# Altium schematic sheet CW551_Mainboard_MCU.SchDoc
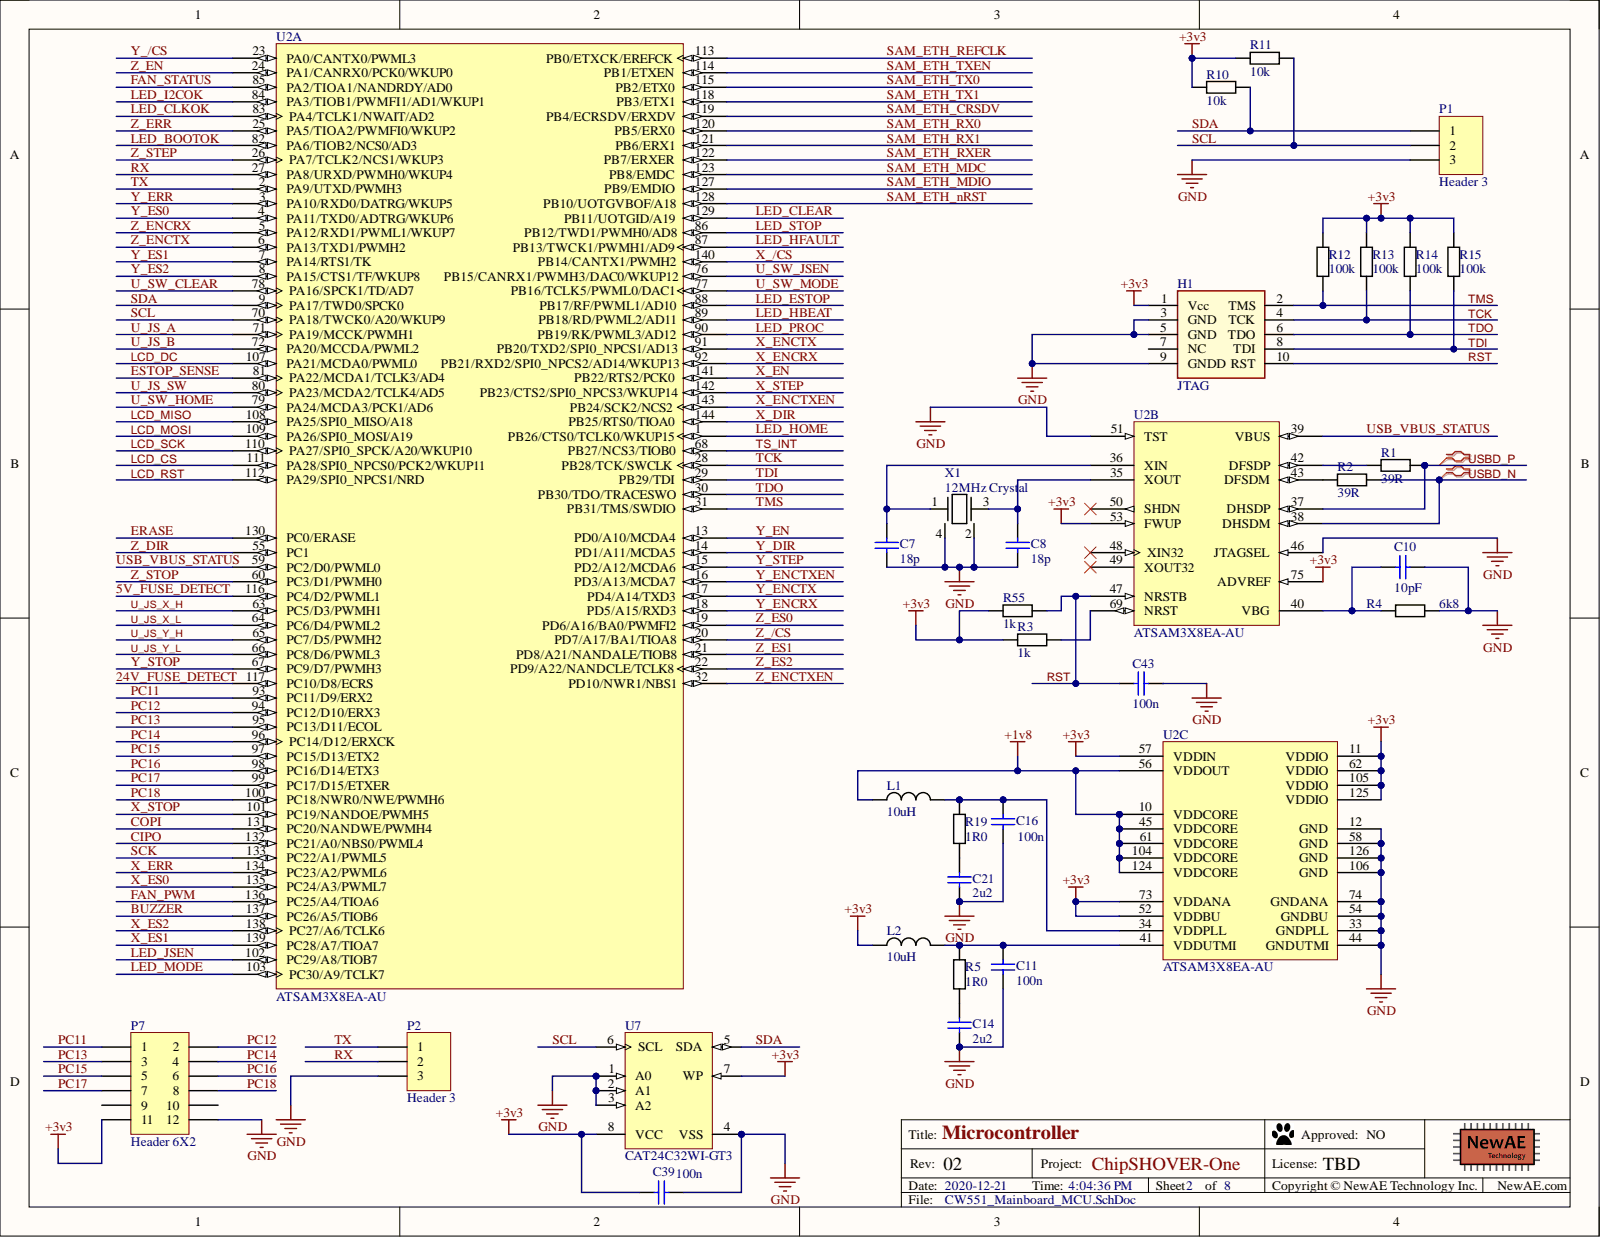
[diagram p0: CW551_Mainboard_MCU.SchDoc]

## Parts
C7  18p  [CAPC0402_M]
C8  18p  [CAPC0402_M]
C10  10pF  [CAPC0603_M]
C11  100n  [CAPC0603_M]
C14  2u2  [CAPC0603_M]
C16  100n  [CAPC0603_M]
C21  2u2  [CAPC0603_M]
C39  100n  [CAPC0603_M]
C43  100n  [CAPC0603_M]
H1  JTAG  [0.5mm_SMD_Header_5x2]
L1  10uH  [IND_0603]
L2  10uH  [IND_0603]
P1  Header 3  [HDR1X3]
P2  Header 3  [HDR1X3]
P7  Header 6X2  [HDR2X6]
R1  39R  [RESC1608X55N]
R2  39R  [RESC1608X55N]
R3  1k  [0603]
R4  6k8  [0603]
R5  1R0  [RESC1608X55N]
R10  10k  [0603]
R11  10k  [0603]
R12  100k  [RESC1608X55N]
R13  100k  [RESC1608X55N]
R14  100k  [RESC1608X55N]
R15  100k  [RESC1608X55N]
R19  1R0  [RESC1608X55N]
R55  1k  [0603]
U2  ATSAM3X8EA-AU  [LQFP144_L]  (parts A,B,C)
U7  CAT24C32WI-GT3  [ONSC-SOIC-8-751BD-01_M]
X1  12MHz Crystal  Component Group: Crystal  [ABM8G]

## Nets
+1v8: L1.1 U2.10(VDDCORE) U2.45(VDDCORE) U2.56(VDDOUT) U2.61(VDDCORE) U2.104(VDDCORE) U2.124(VDDCORE)
+3v3: C39.1 H1.1(Vcc) L2.1 P7.11 R3.2 R10.1 R11.1 R12.1 R13.1 R14.1 R15.1 R55.2 U2.11(VDDIO) U2.52(VDDBU) U2.53(FWUP) U2.57(VDDIN) U2.62(VDDIO) U2.73(VDDANA) U2.75(ADVREF) U2.105(VDDIO) U2.125(VDDIO) U7.7(WP) U7.8(VCC)
GND: C7.2 C8.2 C10.1 C11.2 C14.2 C16.2 C21.2 C39.2 C43.2 H1.3(GND) H1.5(GND) H1.9(GNDD) P1.3 P2.3 P7.12 R4.1 U2.12(GND) U2.33(GNDPLL) U2.44(GNDUTMI) U2.46(JTAGSEL) U2.51(TST) U2.54(GNDBU) U2.58(GND) U2.74(GNDANA) U2.106(GND) U2.126(GND) U7.1(A0) U7.2(A1) U7.3(A2) U7.4(VSS) X1.2 X1.4
5V_FUSE_DETECT: U2.116(PC4/D2/PWML1)
24V_FUSE_DETECT: U2.117(PC10/D8/ECRS)
BUZZER: U2.137(PC26/A5/TIOB6)
CIPO: U2.132(PC21/A0/NBS0/PWML4)
COPI: U2.131(PC20/NANDWE/PWMH4)
ERASE: U2.130(PC0/ERASE)
ESTOP_SENSE: U2.81(PA22/MCDA1/TCLK3/AD4)
FAN_PWM: U2.136(PC25/A4/TIOA6)
FAN_STATUS: U2.85(PA2/TIOA1/NANDRDY/AD0)
LCD_CS: U2.111(PA28/SPI0_NPCS0/PCK2/WKUP11)
LCD_DC: U2.107(PA21/MCDA0/PWML0)
LCD_MISO: U2.108(PA25/SPI0_MISO/A18)
LCD_MOSI: U2.109(PA26/SPI0_MOSI/A19)
LCD_RST: U2.112(PA29/SPI0_NPCS1/NRD)
LCD_SCK: U2.110(PA27/SPI0_SPCK/A20/WKUP10)
LED_BOOTOK: U2.82(PA6/TIOB2/NCS0/AD3)
LED_CLEAR: U2.129(PB11/UOTGID/A19)
LED_CLKOK: U2.83(PA4/TCLK1/NWAIT/AD2)
LED_ESTOP: U2.88(PB17/RF/PWML1/AD10)
LED_HBEAT: U2.89(PB18/RD/PWML2/AD11)
LED_HFAULT: U2.87(PB13/TWCK1/PWMH1/AD9)
LED_HOME: U2.1(PB26/CTS0/TCLK0/WKUP15)
LED_I2COK: U2.84(PA3/TIOB1/PWMFI1/AD1/WKUP1)
LED_JSEN: U2.102(PC29/A8/TIOB7)
LED_MODE: U2.103(PC30/A9/TCLK7)
LED_PROC: U2.90(PB19/RK/PWML3/AD12)
LED_STOP: U2.86(PB12/TWD1/PWMH0/AD8)
PC11: P7.1 U2.93(PC11/D9/ERX2)
PC12: P7.2 U2.94(PC12/D10/ERX3)
PC13: P7.3 U2.95(PC13/D11/ECOL)
PC14: P7.4 U2.96(PC14/D12/ERXCK)
PC15: P7.5 U2.97(PC15/D13/ETX2)
PC16: P7.6 U2.98(PC16/D14/ETX3)
PC17: P7.7 U2.99(PC17/D15/ETXER)
PC18: P7.8 U2.100(PC18/NWR0/NWE/PWMH6)
RST: C43.1 H1.10(RST) R55.1 U2.47(NRSTB)
RX: P2.2 U2.27(PA8/URXD/PWMH0/WKUP4)
SAM_ETH_CRSDV: U2.119(PB4/ECRSDV/ERXDV)
SAM_ETH_MDC: U2.123(PB8/EMDC)
SAM_ETH_MDIO: U2.127(PB9/EMDIO)
SAM_ETH_nRST: U2.128(PB10/UOTGVBOF/A18)
SAM_ETH_REFCLK: U2.113(PB0/ETXCK/EREFCK)
SAM_ETH_RX0: U2.120(PB5/ERX0)
SAM_ETH_RX1: U2.121(PB6/ERX1)
SAM_ETH_RXER: U2.122(PB7/ERXER)
SAM_ETH_TX0: U2.115(PB2/ETX0)
SAM_ETH_TX1: U2.118(PB3/ETX1)
SAM_ETH_TXEN: U2.114(PB1/ETXEN)
SCK: U2.133(PC22/A1/PWML5)
SCL: P1.2 R11.2 U2.70(PA18/TWCK0/A20/WKUP9) U7.6(SCL)
SDA: P1.1 R10.2 U2.9(PA17/TWD0/SPCK0) U7.5(SDA)
TCK: H1.4(TCK) R13.2 U2.28(PB28/TCK/SWCLK)
TDI: H1.8(TDI) R15.2 U2.29(PB29/TDI)
TDO: H1.6(TDO) R14.2 U2.30(PB30/TDO/TRACESWO)
TMS: H1.2(TMS) R12.2 U2.31(PB31/TMS/SWDIO)
TS_INT: U2.68(PB27/NCS3/TIOB0)
TX: P2.1 U2.2(PA9/UTXD/PWMH3)
U_JS_A: U2.71(PA19/MCCK/PWMH1)
U_JS_B: U2.72(PA20/MCCDA/PWML2)
U_JS_SW: U2.80(PA23/MCDA2/TCLK4/AD5)
U_JS_X_H: U2.63(PC5/D3/PWMH1)
U_JS_X_L: U2.64(PC6/D4/PWML2)
U_JS_Y_H: U2.65(PC7/D5/PWMH2)
U_JS_Y_L: U2.66(PC8/D6/PWML3)
U_SW_CLEAR: U2.78(PA16/SPCK1/TD/AD7)
U_SW_HOME: U2.79(PA24/MCDA3/PCK1/AD6)
U_SW_JSEN: U2.76(PB15/CANRX1/PWMH3/DAC0/WKUP12)
U_SW_MODE: U2.77(PB16/TCLK5/PWML0/DAC1)
USB_VBUS_STATUS: U2.39(VBUS) U2.59(PC2/D0/PWML0)
USBD_N: R2.1 U2.38(DHSDM)
USBD_P: R1.2 U2.37(DHSDP)
X_/CS: U2.140(PB14/CANTX1/PWMH2)
X_DIR: U2.144(PB25/RTS0/TIOA0)
X_EN: U2.141(PB22/RTS2/PCK0)
X_ENCRX: U2.92(PB21/RXD2/SPI0_NPCS2/AD14/WKUP13)
X_ENCTX: U2.91(PB20/TXD2/SPI0_NPCS1/AD13)
X_ENCTXEN: U2.143(PB24/SCK2/NCS2)
X_ERR: U2.134(PC23/A2/PWML6)
X_ES0: U2.135(PC24/A3/PWML7)
X_ES1: U2.139(PC28/A7/TIOA7)
X_ES2: U2.138(PC27/A6/TCLK6)
X_STEP: U2.142(PB23/CTS2/SPI0_NPCS3/WKUP14)
X_STOP: U2.101(PC19/NANDOE/PWMH5)
Y_/CS: U2.23(PA0/CANTX0/PWML3)
Y_DIR: U2.14(PD1/A11/MCDA5)
Y_EN: U2.13(PD0/A10/MCDA4)
Y_ENCRX: U2.18(PD5/A15/RXD3)
Y_ENCTX: U2.17(PD4/A14/TXD3)
Y_ENCTXEN: U2.16(PD3/A13/MCDA7)
Y_ERR: U2.3(PA10/RXD0/DATRG/WKUP5)
Y_ES0: U2.4(PA11/TXD0/ADTRG/WKUP6)
Y_ES1: U2.7(PA14/RTS1/TK)
Y_ES2: U2.8(PA15/CTS1/TF/WKUP8)
Y_STEP: U2.15(PD2/A12/MCDA6)
Y_STOP: U2.67(PC9/D7/PWMH3)
Z_/CS: U2.20(PD7/A17/BA1/TIOA8)
Z_DIR: U2.55(PC1)
Z_EN: U2.24(PA1/CANRX0/PCK0/WKUP0)
Z_ENCRX: U2.5(PA12/RXD1/PWML1/WKUP7)
Z_ENCTX: U2.6(PA13/TXD1/PWMH2)
Z_ENCTXEN: U2.32(PD10/NWR1/NBS1)
Z_ERR: U2.25(PA5/TIOA2/PWMFI0/WKUP2)
Z_ES0: U2.19(PD6/A16/BA0/PWMFI2)
Z_ES1: U2.21(PD8/A21/NANDALE/TIOB8)
Z_ES2: U2.22(PD9/A22/NANDCLE/TCLK8)
Z_STEP: U2.26(PA7/TCLK2/NCS1/WKUP3)
Z_STOP: U2.60(PC3/D1/PWMH0)
NetC7_1: C7.1 U2.36(XIN) X1.1
NetC8_1: C8.1 U2.35(XOUT) X1.3
NetC10_2: C10.2 R4.2 U2.40(VBG)
NetC11_1: C11.1 L2.2 R5.1 U2.41(VDDUTMI)
NetC14_1: C14.1 R5.2
NetC16_1: C16.1 L1.2 R19.1 U2.34(VDDPLL)
NetC21_1: C21.1 R19.2
NetR1_1: R1.1 U2.42(DFSDP)
NetR2_2: R2.2 U2.43(DFSDM)
NetR3_1: R3.1 U2.69(NRST)

Not connected: H1 7(NC); P7 9 10; U2 48(XIN32) 49(XOUT32) 50(SHDN)

## Library data of the parts
C7 C8 = CCHIP-18p-0402: 18p, 0402; Manufacture Part Number 1: GRM1555C1H180JA01D; Case-EIA: 0402; Component Group: Capacitor; 0_TEMP: CAPC0402_M; Component Kind: Standard; Component Type: Standard; LCSC Equivalent: C106202; Library Name: CW550_MAIN.SCHLIB; Mounting Technology: SMT; Part Number: CCHIP-18p-0402; Pin Count: 2; Supplier 1: Digi-Key; Supplier Part Number 1: 490-5858-1-ND; Value: 18p
C10 = CCHIP-100n-0603: Cap. 10pF, 1%, 0603; Manufacture 1: Kemet; Manufacture Part Number 1: C0603C100F5GAC7867; Part Number: X00X; Case-EIA: 0603; Case-Metric: 1608; Component Group: Capacitor; Rated Voltage: 50V; 0_TEMP: CAPC0603_M; Component Kind: Standard; Component Type: Standard; LCSC Equivalent: C1591; Library Name: CW550_MAIN.SCHLIB; Mounting Technology: SMT; Pin Count: 2; Supplier 1: Digi-Key; Supplier Part Number 1: 399-9958-1-ND; Value: 10pF
C11 C16 C39 C43 = CCHIP-100n-0603: 100n, 0603; Manufacture 1: Kemet; Manufacture Part Number 1: C0603C104K5RACTU; Case-EIA: 0603; Case-Metric: 1608; Component Group: Capacitor; Rated Voltage: 50V; 0_TEMP: CAPC0603_M; Component Kind: Standard; Component Type: Standard; LCSC Equivalent: C1591; Library Name: CW550_MAIN.SCHLIB; Mounting Technology: SMT; Part Number: CCHIP-100n-0603; Pin Count: 2; Supplier 1: Digi-Key; Supplier Part Number 1: 399-5089-2-ND; Value: 100n
C14 C21 = CCHIP-2u2-0603: 2u2, 0603; Manufacture 1: Samsung; Manufacture Part Number 1: CL10B225KP8NNNC; Case-EIA: 0603; Case-Metric: 1608; Component Group: Capacitor; Rated Voltage: 10VDC; 0_TEMP: CAPC0603_M; Component Kind: Standard; Component Type: Standard; LCSC Equivalent: C57895; Library Name: CW550_MAIN.SCHLIB; Mounting Technology: SMT; Part Number: CCHIP-2u2-0603; Pin Count: 2; Supplier 1: Digi-Key; Supplier Part Number 1: 1276-1134-2-ND; Value: 2u2
H1 = Cortex_Debug_Header_10Pin: 10 Pin Cortex Program & Debug 0.5mm pitch; Manufacture 1: Samtec Inc; Manufacture Part Number 1: FTSH-105-01-L-DV-007-K; Component Group: Connector; PP Material Stack: 0; 0_TEMP: 0.5mm_SMD_Header_5x2; Component Kind: Standard; Component Type: Standard; Functionality: JTAG; Library Name: CW550.SCHLIB; Mounting Technology: SMT; Part Number: Cortex_Debug_Header_10Pin; Pin Count: 10; PP Rotation: 0; RoHS: Yes; Supplier 1: Digi-Key; Supplier Part Number 1: SAM9438-ND
L1 L2 = 10uH_0603: FIXED IND 10UH 300MA 600 MOHM; Manufacture 1: TDK; Manufacture Part Number 1: MLZ1608N100LT000; Component Group: Inductor; PP Material Stack: 0; 0_TEMP: IND_0603; Component Kind: Standard; Component Type: Standard; Library Name: CW550_MAIN.SCHLIB; Mounting Technology: SMT; Part Number: 10uH_0603; Pin Count: 2; PP Rotation: 0; RoHS: Yes; Supplier 1: Digi-Key; Supplier Part Number 1: 445-6755-1-ND; Value: 10uH
P1 P2 = Header 3: Header, 3-Pin; Component Kind: Standard; LatestRevisionDate: 17-Jul-2002; LatestRevisionNote: Re-released for DXP Platform.; Library Name: Miscellaneous Connectors.IntLib; Signal Integrity: Connector; Publisher: Altium Limited
P7 = Header 6X2: Header, 6-Pin, Dual row; Component Kind: Standard; LatestRevisionDate: 17-Jul-2002; LatestRevisionNote: Re-released for DXP Platform.; Library Name: Miscellaneous Connectors.IntLib; Signal Integrity: Connector; Publisher: Altium Limited
R1 R2 = RCHIP-39R-0603: 39R res, 0603, 1%, 1/10W; Manufacture 1: Yageo; Manufacture Part Number 1: RC0603FR-0739RL; Case-EIA: 0603; Case-Metric: 1608; Component Group: Resistor; Rated Power: 0.1W; Rated Voltage: 75VDC; 0_TEMP: RESC1608X55N; Component Kind: Standard; Component Type: Standard; Library Name: CW550_MAIN.SCHLIB; Mounting Technology: SMT; Part Number: RCHIP-39R-0603; Pin Count: 2; Supplier 1: Digi-Key; Supplier Part Number 1: 311-39.0HRCT-ND; Temperature Range: -55C to 155C; Tolerance: 1%; Value: 39R
R3 R55 = RCHIP-1K-0603: 1k res, 0603, 1%, 1/10W; Manufacture 1: Yageo; Manufacture Part Number 1: RC0603FR-071KL; Case-Metric: 1608; Component Group: Resistor; Database Table Name: main; Rated Power: 0.1W; Rated Voltage: 75VDC; Case-EIA: 0603; Component Kind: Standard; Component Type: Standard; Library Name: NewAE_AltiumLib.DBLib; Mounting Technology: SMT; Part Number: RCHIP-1K-0603; Pin Count: 2; Supplier 1: Digi-Key; Supplier Part Number 1: 311-1.00KHRCT-ND; Temperature Range: -55C to 155C; Tolerance: 1%; Value: 1k
R4 = RCHIP-6K8-0603: 6k8 res, 0603, 1%, 1/10W; Manufacture 1: Yageo; Manufacture Part Number 1: RC0603FR-076K8L; Case-Metric: 1608; Component Group: Resistor; Database Table Name: main; Rated Power: 0.1W; Rated Voltage: 75VDC; Case-EIA: 0603; Component Kind: Standard; Component Type: Standard; Library Name: NewAE_AltiumLib.DBLib; Mounting Technology: SMT; Part Number: RCHIP-6K8-0603; Pin Count: 2; Supplier 1: Digi-Key; Supplier Part Number 1: 311-6.80KHRCT-ND; Temperature Range: -55C to 155C; Tolerance: 1%; Value: 6k8
R5 R19 = RCHIP-1R0-0603: 1R0 res, 0603, 1%, 1/10W; Manufacture 1: Yageo; Manufacture Part Number 1: RC0603FR-071RL; Case-EIA: 0603; Case-Metric: 1608; Component Group: Resistor; Rated Power: 0.1W; Rated Voltage: 75VDC; 0_TEMP: RESC1608X55N; Component Kind: Standard; Component Type: Standard; Library Name: CW550_MAIN.SCHLIB; Mounting Technology: SMT; Part Number: RCHIP-1R0-0603; Pin Count: 2; Supplier 1: Digi-Key; Supplier Part Number 1: 311-1.00HRCT-ND; Temperature Range: -55C to 155C; Tolerance: 1%; Value: 1R0
R10 R11 = RCHIP-10K-0603: 10k res, 0603, 1%, 1/10W; Manufacture 1: Yageo; Manufacture Part Number 1: RC0603FR-0710KL; Case-Metric: 1608; Component Group: Resistor; Database Table Name: main; Rated Power: 0.1W; Rated Voltage: 75VDC; Case-EIA: 0603; Component Kind: Standard; Component Type: Standard; Library Name: NewAE_AltiumLib.DBLib; Mounting Technology: SMT; Part Number: RCHIP-10K-0603; Pin Count: 2; Supplier 1: Digi-Key; Supplier Part Number 1: 311-10.0KHRCT-ND; Temperature Range: -55C to 155C; Tolerance: 1%; Value: 10k
R12-R15 = RCHIP-100K-0603: 100k res, 0603, 1%, 1/10W; Manufacture 1: Yageo; Manufacture Part Number 1: RC0603FR-07100KL; Case-EIA: 0603; Case-Metric: 1608; Component Group: Resistor; Rated Power: 0.1W; Rated Voltage: 75VDC; 0_TEMP: RESC1608X55N; Component Kind: Standard; Component Type: Standard; Library Name: CW550_MAIN.SCHLIB; Mounting Technology: SMT; Part Number: RCHIP-100K-0603; Pin Count: 2; Supplier 1: Digi-Key; Supplier Part Number 1: 311-100KHRCT-ND; Temperature Range: -55C to 155C; Tolerance: 1%; Value: 100k
U2 = CMP-0076-00188-1: SAM3X ARM Cortex-M3 Flash-based Microcontroller, 512 KB Flash, 96 KB SRAM, 103 User I/Os, 1.62 to 3.6 V, -40 to 85 degC, 144-Pin LQFP, RoHS; Manufacturer: Atmel; # of Touch Channels: 32; ADC Channels: 16; ADC Resolution: 12 bits; CAN: 2; Flash: 512 Kbytes; Max I/O Pins: 103; Max. Operating Freq.: 84 MHz; SPI: 4; SRAM: 96 Kbytes; UART: 5; Component Kind: Standard; ComponentLink1Description: Manufacturer URL; ComponentLink1URL: http://www.atmel.com/; ComponentLink2Description: Datasheet; ComponentLink2URL: http://www.atmel.com/Images/doc11057.pdf; ComponentLink3Description: Package Specification; ComponentLink3URL: http://www.atmel.com/Images/doc11057.pdf; DAC Channels: 2; DatasheetVersion: 11057B; LCSC Part: C79305; Library Name: Altium Content Vault; Mounting Technology: Surface Mount; Operating Voltage: 1.62 to 3.6 V; PackageDescription: 100-Pin Quad Flat Package, Body 20 x 20 mm, Pitch 0.5 mm; PackageReference: LQFP-144; PackageVersion: 11057B; PartNumber: ATSAM3X8EA-AU; RoHS: TRUE; Temp. Range: -40 to 85 degC
U7 = CMP-1679-00009-1: I2C CMOS Serial EEPROM, 32 Kb, 1.7 to 5.5 V, -40 to 85 degC, 8-Pin SOIC (751BD), RoHS, Tape and Reel; Case\Package: TO-226-3; Elements: 1; Frequency: 300 MHz; hFE Min: 100; Max Power Dissipation: 625 mW; Vce Max: 1 V; Component Kind: Standard; ComponentLink1Description: Manufacturer URL; ComponentLink1URL: http://www.onsemi.com/; ComponentLink2Description: Datasheet; ComponentLink2URL: http://www.onsemi.com/pub_link/Collateral/CAT24C32-D.PDF; ComponentLink3Description: Package Specification; ComponentLink3URL: http://www.onsemi.com/pub_link/Collateral/751BD.PDF; DatasheetVersion: Rev. 24, 06/2015; Height: 5.33 mm; Length: 5.2 mm; Library Name: Altium Content Vault; Max Operating Temperature: 150 degC; Min Operating Temperature: -55 degC; Mount: Through Hole; Package Quantity: 1000; PackageDescription: 8-Pin Small Outline Integrated Circuit, Body 4.9 x 3.9 mm, Pitch 1.27 mm; PackageReference: SOIC-8-751BD-01; Packaging: Bulk; Pins: 3; Radiation Hardening: No; REACH SVHC: No SVHC; RoHSCompliant: true; Weight: 0.006314 oz; Width: 4.19 mm
X1 = OSC_4pin: CRYSTAL 12.0000MHZ 10PF SMD; Manufacture 1: Abracon LLC; Manufacture Part Number 1: ABM8G-12.000MHZ-4Y-T3; PP Material Stack: 0; 0_TEMP: ABM8G; Component Kind: Standard; Component Type: Standard; Library Name: CW550_MAIN.SCHLIB; Mounting Technology: SMT; Part Number: ABM8G; Pin Count: 4; PP Rotation: 0; RoHS: Yes; Supplier 1: Digi-Key; Supplier Part Number 1: 535-10901-1-ND
